# Altium schematic sheet violent fan.SchDoc
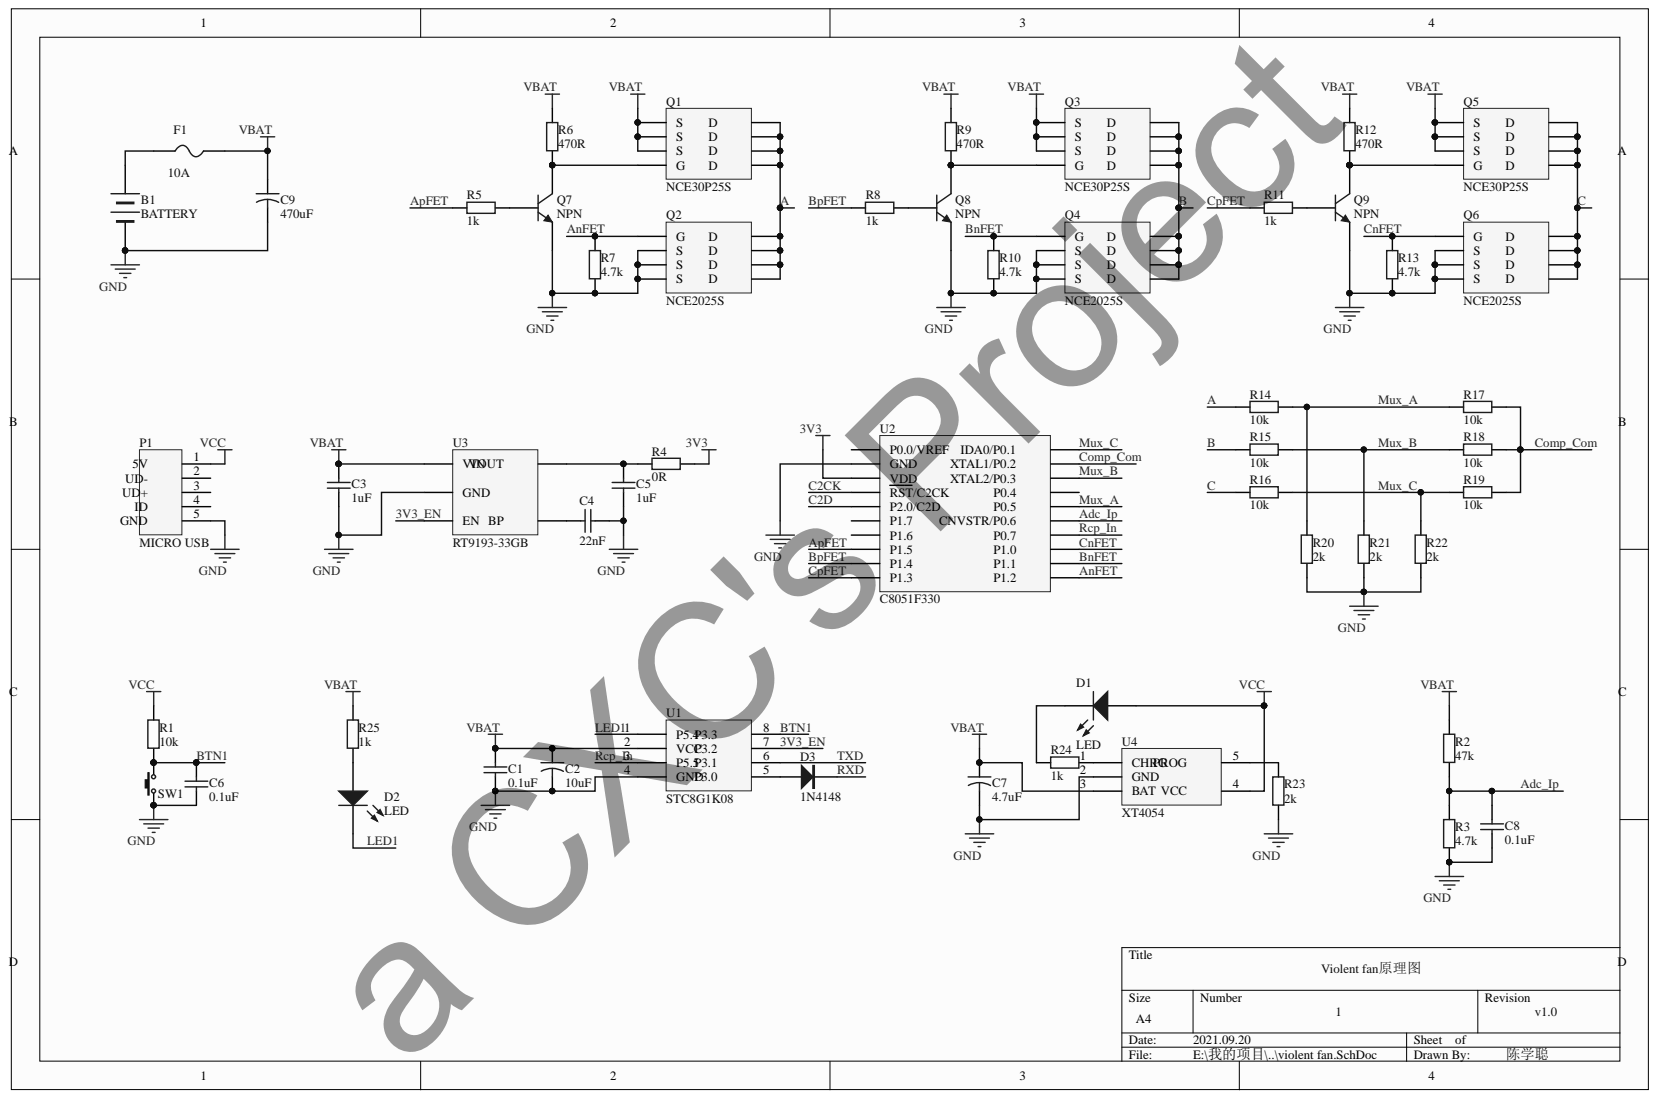
[diagram p0: violent fan.SchDoc]

## Parts
B1  BATTERY  [18650电池座-直插式]
C1  0.1uF  [C-0603-M]
C2  10uF  [C-钽电容-3216-N]
C3  1uF  [C-0603-M]
C4  22nF  [C-0603-M]
C5  1uF  [C-0603-M]
C6  0.1uF  [C-0603-M]
C7  4.7uF  [C-钽电容-3216-N]
C8  0.1uF  [C-0603-M]
C9  470uF  [CAP 5.0*10*16-H - GD]
D1  LED  [LED-0603-Red]
D2  LED  [LED-0603-Blue]
D3  1N4148  [SOD-323]
F1  10A  [FU 1808]
P1  MICRO USB  [MICRO USB-立式卷边]
Q1  NCE30P25S  [SOP8_L]
Q2  NCE2025S  [SOP8_L]
Q3  NCE30P25S  [SOP8_L]
Q4  NCE2025S  [SOP8_L]
Q5  NCE30P25S  [SOP8_L]
Q6  NCE2025S  [SOP8_L]
Q7  NPN  [SOT-23]
Q8  NPN  [SOT-23]
Q9  NPN  [SOT-23]
R1  10k  [R-0603-M]
R2  47k  [R-0603-M]
R3  4.7k  [R-0603-M]
R4  0R  [R-0603-M]
R5  1k  [R-0603-M]
R6  470R  [R-0603-M]
R7  4.7k  [R-0603-M]
R8  1k  [R-0603-M]
R9  470R  [R-0603-M]
R10  4.7k  [R-0603-M]
R11  1k  [R-0603-M]
R12  470R  [R-0603-M]
R13  4.7k  [R-0603-M]
R14  10k  [R-0603-M]
R15  10k  [R-0603-M]
R16  10k  [R-0603-M]
R17  10k  [R-0603-M]
R18  10k  [R-0603-M]
R19  10k  [R-0603-M]
R20  2k  [R-0603-M]
R21  2k  [R-0603-M]
R22  2k  [R-0603-M]
R23  2k  [R-0603-M]
R24  1k  [R-0603-M]
R25  1k  [R-0603-M]
SW1  Button  [TSW SMD-6*6*4.3]  (value not drawn)
U1  STC8G1K08  [SOP8_L]
U2  C8051F330  [QFN20 4x4_L]
U3  RT9193-33GB  [SOT23-5]
U4  XT4054  [SOT23-5]

## Nets
3V3: R4.2 U2.3
GND: B1.2 C1.2 C2.2 C3.2 C4.2 C5.1 C6.1 C7.2 C8.2 C9.2 P1.5 Q2.1 Q2.2 Q2.3 Q4.1 Q4.2 Q4.3 Q6.1 Q6.2 Q6.3 Q7.2 Q8.2 Q9.2 R3.2 R7.2 R10.1 R13.1 R20.2 R21.2 R22.2 R23.2 SW1.1 U1.4 U2.2 U3.2 U4.2
VBAT: C1.1 C2.1 C3.1 C7.1 C9.1 F1.2 Q1.1 Q1.2 Q1.3 Q3.1 Q3.2 Q3.3 Q5.1 Q5.2 Q5.3 R2.1 R6.1 R9.2 R12.2 R25.2 U1.2 U3.1 U4.3
VCC: D1.1 P1.1 R1.2 U4.4
3V3_EN: U1.7 U3.3
A: Q1.5 Q1.6 Q1.7 Q1.8 Q2.5 Q2.6 Q2.7 Q2.8 R14.2
ADC_IP: C8.1 R2.2 R3.1 U2.15
ANFET: Q2.4 R7.1 U2.11
APFET: R5.2 U2.8
B: Q3.5 Q3.6 Q3.7 Q3.8 Q4.5 Q4.6 Q4.7 Q4.8 R15.2
BNFET: Q4.4 R10.2 U2.12
BPFET: R8.1 U2.9
BTN1: C6.2 R1.1 SW1.2 U1.8
C: Q5.5 Q5.6 Q5.7 Q5.8 Q6.5 Q6.6 Q6.7 Q6.8 R16.2
C2CK: U2.4
C2D: U2.5
CNFET: Q6.4 R13.2 U2.13
COMP_COM: R17.1 R18.1 R19.1 U2.19
CPFET: R11.2 U2.10
LED1: D2.2 U1.1
MUX_A: R14.1 R17.2 R20.1 U2.16
MUX_B: R15.1 R18.2 R21.1 U2.18
MUX_C: R16.1 R19.2 R22.1 U2.20
RCP_IN: U1.3 U2.14
RXD: D3.2
TXD: U1.6
NetB1_1: B1.1 F1.1
NetC4_1: C4.1 U3.4
NetC5_2: C5.2 R4.1 U3.5
NetD1_2: D1.2 R24.1
NetD2_1: D2.1 R25.1
NetD3_1: D3.1 U1.5
NetQ1_4: Q1.4 Q7.3 R6.2
NetQ3_4: Q3.4 Q8.3 R9.1
NetQ5_4: Q5.4 Q9.3 R12.1
NetQ7_1: Q7.1 R5.1
NetQ8_1: Q8.1 R8.2
NetQ9_1: Q9.1 R11.1
NetR23_1: R23.1 U4.5
NetR24_2: R24.2 U4.1

Not connected: P1 2 3 4; U2 1 6 7 17

## Library data of the parts
B1 = BATTERY: 电池座、电池位; Component Kind: Standard; Library Name: cxc’s Integrated_Library.IntLib
C1 C6 C8 = Cap: 无极性电容; Component Kind: Standard; LatestRevisionDate: 17-Jul-2002; LatestRevisionNote: Re-released for DXP Platform.; PackageDescription: Radial Cap, Thru-Hole; 2 Leads; 0.3 in Pin Spacing; PackageReference: RAD-0.3; Published: 8-Jun-2000; Value: 0.1uF; Library Name: cxc’s Integrated_Library.IntLib; Publisher: Altium Limited
C2 = Cap_1: 有极性电容; Component Kind: Standard; PackageDescription: Capacitor; 2 Leads; PackageReference: CAPR5-4X5; Published: 23-Sep-2002; Value: 10uF; Library Name: cxc’s Integrated_Library.IntLib; Publisher: Altium Limited
C3-C5 = Cap: 无极性电容; Value: 0.1uF; Component Kind: Standard; LatestRevisionDate: 17-Jul-2002; LatestRevisionNote: Re-released for DXP Platform.; PackageDescription: Radial Cap, Thru-Hole; 2 Leads; 0.3 in Pin Spacing; PackageReference: RAD-0.3; Published: 8-Jun-2000; Library Name: cxc’s Integrated_Library.IntLib; Publisher: Altium Limited
C7 C9 = Cap_1: 有极性电容; Value: 10uF; Component Kind: Standard; PackageDescription: Capacitor; 2 Leads; PackageReference: CAPR5-4X5; Published: 23-Sep-2002; Library Name: cxc’s Integrated_Library.IntLib; Publisher: Altium Limited
D1 D2 = LED: Typical RED, GREEN, YELLOW, AMBER GaAs LED; Component Kind: Standard; LatestRevisionDate: 17-Jul-2002; LatestRevisionNote: Re-released for DXP Platform.; PackageDescription: SM LED; 2 Flat Leads; PackageReference: 3.2X1.6X1.1; PackageVersion: Feb-2002; Published: 8-Jun-2000; Library Name: cxc’s Integrated_Library.IntLib; Publisher: Altium Limited
D3 = 1N4148: 高速开关二极管; 版权归属: 源创客; BOM名称: 高速开关二极管; Component Kind: Standard; 学习资源: www.ooocke.com; Library Name: cxc’s Integrated_Library.IntLib
F1 = FUSE: 贴片保险丝; 免费技术支持，联系售后: 唯一出售店铺网址https://shop463601444.taobao.com; 封装库来自淘宝卖家  ID：黄晶宝石: 其他店铺购入均为盗版假冒封装库，请立即申请退款，本店3~10元现金奖励; BOM名称: 贴片保险丝; Component Kind: Standard; Value: 10A; Library Name: cxc’s Integrated_Library.IntLib
P1 = MICRO_USB: MICRO USB接口; Component Kind: Standard; Library Name: cxc’s Integrated_Library.IntLib
Q1 Q3 Q5 = NCE30P25S: P沟道-30V/-25A 贴片MOSFET; Component Kind: Standard; Library Name: cxc’s Integrated_Library.IntLib
Q2 Q4 Q6 = NCE2025S: N沟道20V/25A 贴片MOSFET; Component Kind: Standard; Library Name: cxc’s Integrated_Library.IntLib
Q7-Q9 = NPN: NPN型三极管; Code_JEDEC: TO-226-AA; Component Kind: Standard; LatestRevisionDate: 17-Jul-2002; LatestRevisionNote: Re-released for DXP Platform.; PackageDescription: TO, Flat Index; 3 In-Line, Axial Leads; Body Dia. 4.8mm; Leads 0.55 x 0.51 mm (max); PackageReference: TO-226-AA; Published: 8-Jun-2000; Library Name: cxc’s Integrated_Library.IntLib; Publisher: Altium Limited
R1-R4 R6 R7 R9 R10 R12-R23 = Resistor: 电阻; Value: 1k; Component Kind: Standard; LatestRevisionDate: 17-Jul-2002; LatestRevisionNote: Re-released for DXP Platform.; PackageDescription: Axial Device, Thru-Hole; 2 Leads; 0.4 in Pin Spacing; PackageReference: AXIAL-0.4; Published: 8-Jun-2000; Library Name: cxc’s Integrated_Library.IntLib; Publisher: Altium Limited
R5 R8 R11 R24 R25 = Resistor: 电阻; Component Kind: Standard; LatestRevisionDate: 17-Jul-2002; LatestRevisionNote: Re-released for DXP Platform.; PackageDescription: Axial Device, Thru-Hole; 2 Leads; 0.4 in Pin Spacing; PackageReference: AXIAL-0.4; Published: 8-Jun-2000; Value: 1k; Library Name: cxc’s Integrated_Library.IntLib; Publisher: Altium Limited
SW1 = Button: 轻触开关; BOM名称: 3x6轻触开关; 版权归属: 源创客; Component Kind: Standard; 学习资源: www.ooocke.com; Library Name: cxc’s Integrated_Library.IntLib
U1 = STC8G1K08: 增强型51单片机; Component Kind: Standard; Library Name: cxc’s Integrated_Library.IntLib
U2 = C8051F330: silicon labs/8位51内核单片机; Component Kind: Standard; Library Name: cxc’s Integrated_Library.IntLib
U3 = RT9193-33GB: LDO-3.3v; Component Kind: Standard; Library Name: cxc’s Integrated_Library.IntLib
U4 = XT4054: 锂电池充电ic; Component Kind: Standard; Library Name: cxc’s Integrated_Library.IntLib
